# Altium schematic sheet MIXR Power - Connectors.SchDoc
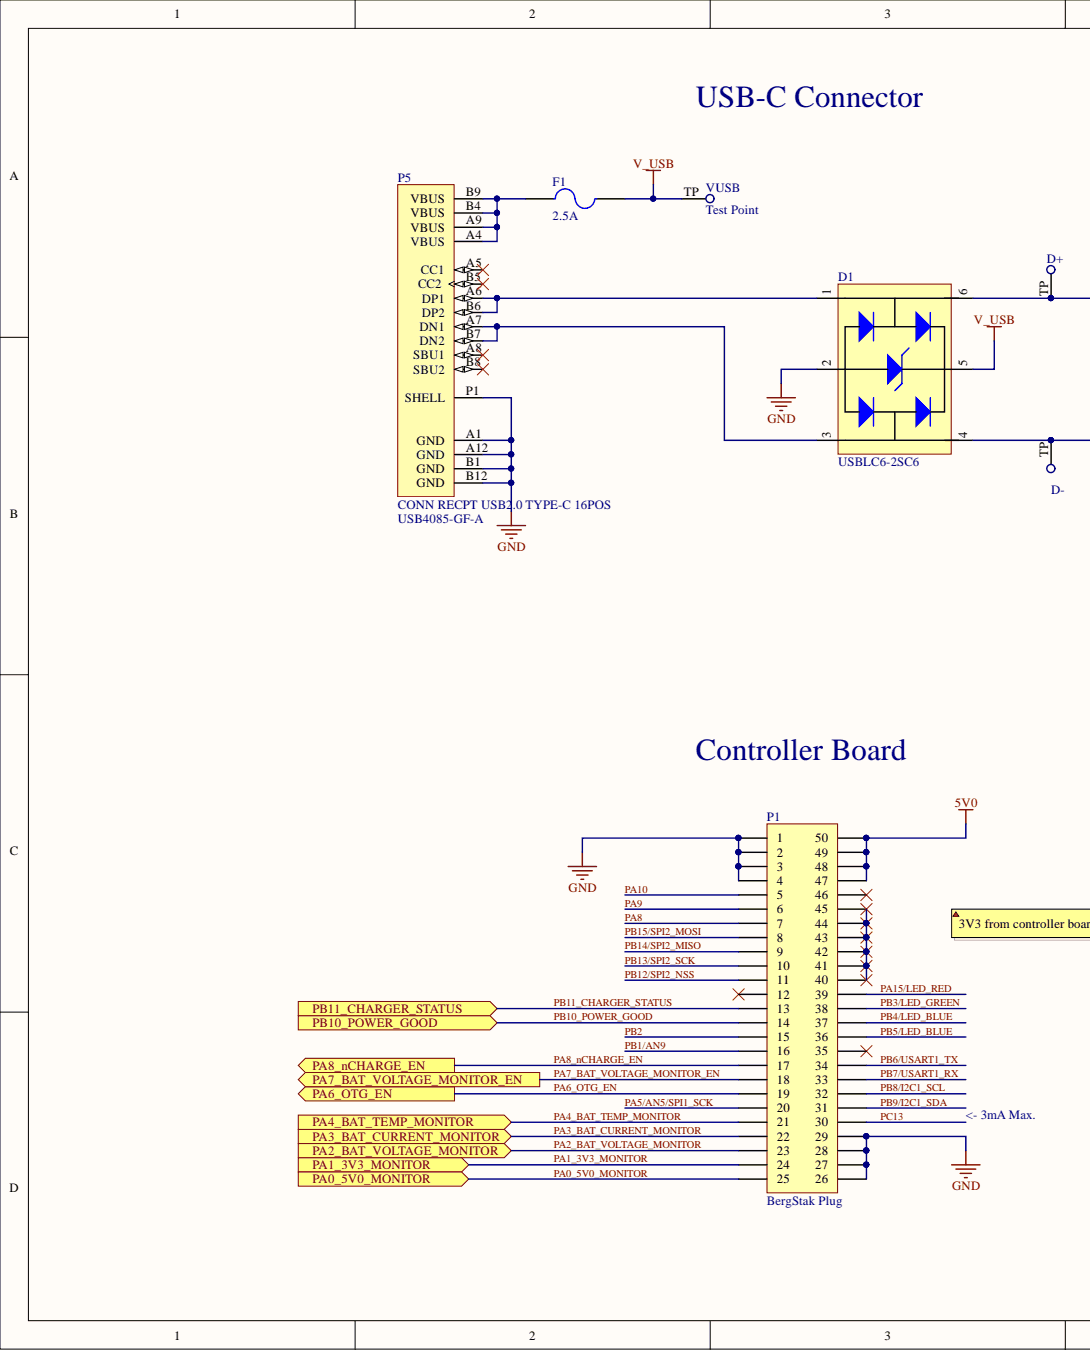
[diagram p0: MIXR Power - Connectors.SchDoc, left]
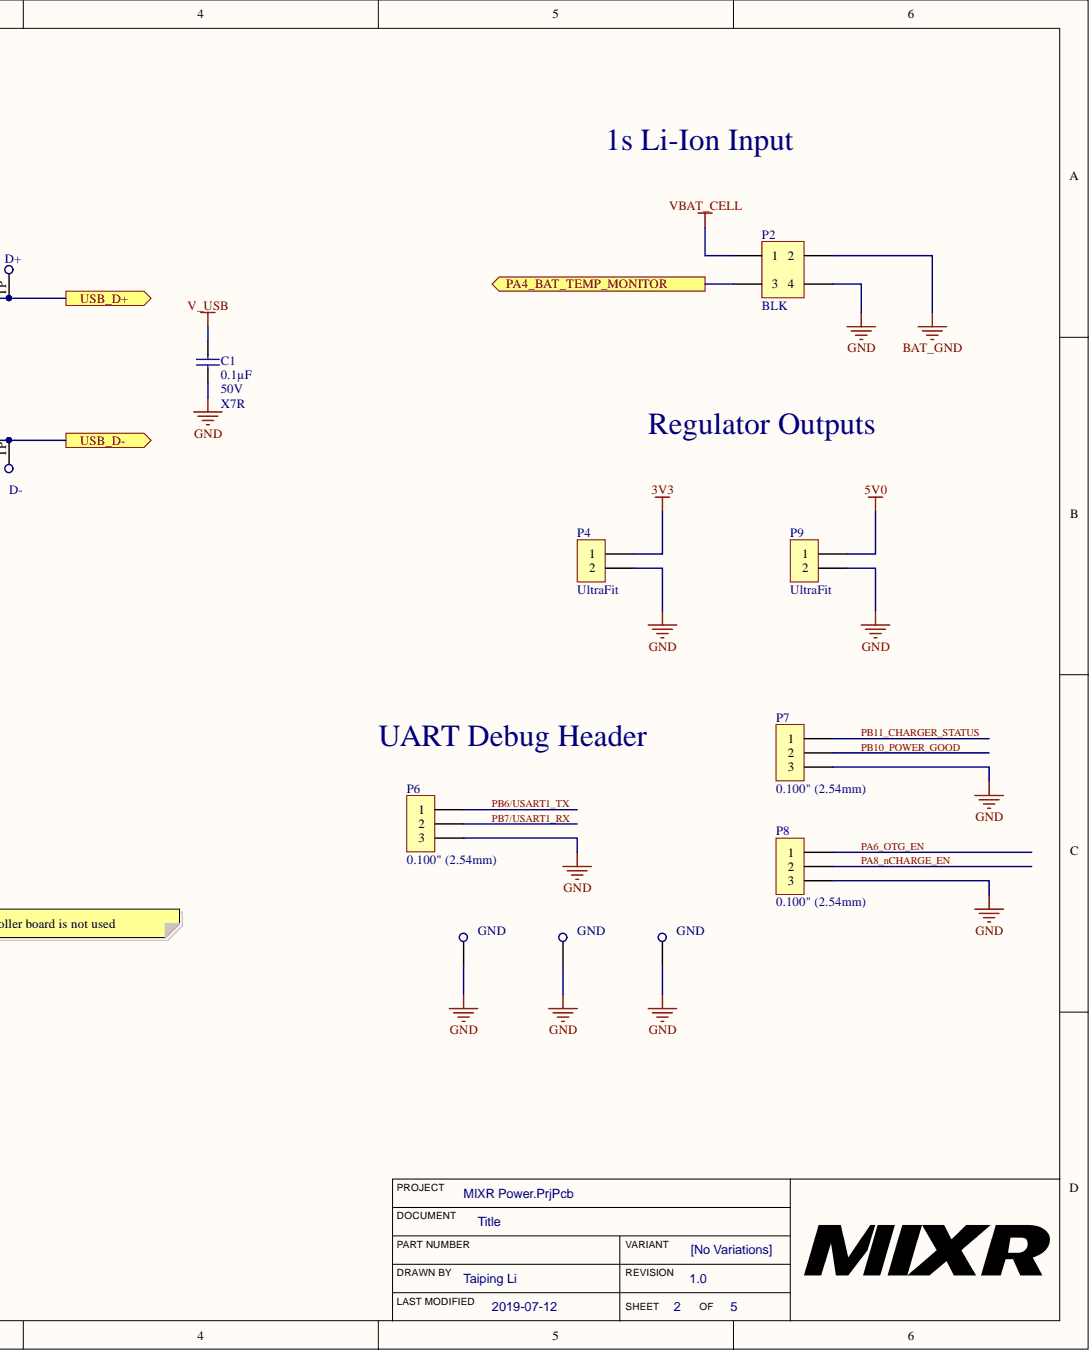
[diagram p1: MIXR Power - Connectors.SchDoc, right]

## Parts
C1  Capacitance: 0.1µF  Temperature Coefficient: X7R  Voltage: 50V  [CAP, 0603]  (hidden comment: CAP CER 0.1UF 50V 10% X7R 0603)
D1  Manufacturer Part Number 1: USBLC6-2SC6  [SOT23-6]  (hidden comment: DIODE TVS 5.25V 17V SOT23-6)
D+  Test Point  [Test Point]  (no BOM)
D-  Test Point  [Test Point]  (no BOM)
F1  Current Rating: 2.5A  [FUSE LITTLEFUSE 0466 1206]  (hidden comment: FUSE 2.5A 32VDC 1206)
GND  Thru Test Point  [Thru Test Point]  (value not drawn)
GND  Thru Test Point  [Thru Test Point]  (value not drawn)
GND  Thru Test Point  [Thru Test Point]  (value not drawn)
P1  Series: BergStak Plug  [CONN, Bergstak 50 Pos Plug]  (hidden comment: CONN 50POS Bergstak Plug 0.02")
P2  BLK  [CONN, 4POS 2 ROW ULTRA-FIT]
P4  Series: UltraFit  [CONN, 2POS ULTRA-FIT]  (hidden comment: CONN 2POS ULTRA-FIT 0.138")
P5  CONN RECPT USB2.0 TYPE-C 16POS  Manufacturer Part Number: USB4085-GF-A  [CONN RECPT USB2.0 TYPE C 16-POS]
P6  Insulation Height: 0.100" (2.54mm)  [CONN, 3POS 0.100" Header, 1 ROW]  (hidden comment: CONN 3POS HEADR MALE 0.1")
P7  Insulation Height: 0.100" (2.54mm)  [CONN, 3POS 0.100" Header, 1 ROW]  (hidden comment: CONN 3POS HEADR MALE 0.1")
P8  Insulation Height: 0.100" (2.54mm)  [CONN, 3POS 0.100" Header, 1 ROW]  (hidden comment: CONN 3POS HEADR MALE 0.1")
P9  Series: UltraFit  [CONN, 2POS ULTRA-FIT]  (hidden comment: CONN 2POS ULTRA-FIT 0.138")
VUSB  Test Point  [Test Point]  (no BOM)

## Ports
PA0_5V0_MONITOR, PA1_3V3_MONITOR, PA2_BAT_VOLTAGE_MONITOR, PA3_BAT_CURRENT_MONITOR, PA4_BAT_TEMP_MONITOR, PA6_OTG_EN, PA7_BAT_VOLTAGE_MONITOR_EN, PA8_nCHARGE_EN, PB10_POWER_GOOD, PB11_CHARGER_STATUS, USB_D+, USB_D-

## Nets
3V3: P4.1
5V0: P1.47 P1.48 P1.49 P1.50 P9.1
BAT_GND: P2.2
GND: C1.2 D1.2 GND.TH_TP P1.1 P1.2 P1.3 P1.4 P1.26 P1.27 P1.28 P1.29 P2.4 P4.2 P5.A1(GND) P5.A12(GND) P5.B1(GND) P5.B12(GND) P5.P1(SHELL) P6.3 P7.3 P8.3 P9.2
V_USB: C1.1 D1.5 F1.2 VUSB.TP
VBAT_CELL: P2.1
PA0_5V0_MONITOR: P1.25 ; port PA0_5V0_MONITOR
PA1_3V3_MONITOR: P1.24 ; port PA1_3V3_MONITOR
PA2_BAT_VOLTAGE_MONITOR: P1.23 ; port PA2_BAT_VOLTAGE_MONITOR
PA3_BAT_CURRENT_MONITOR: P1.22 ; port PA3_BAT_CURRENT_MONITOR
PA4_BAT_TEMP_MONITOR: P1.21 P2.3 ; port PA4_BAT_TEMP_MONITOR
PA5/AN5/SPI1_SCK: P1.20
PA6_OTG_EN: P1.19 P8.1 ; port PA6_OTG_EN
PA7_BAT_VOLTAGE_MONITOR_EN: P1.18 ; port PA7_BAT_VOLTAGE_MONITOR_EN
PA8: P1.7
PA8_nCHARGE_EN: P1.17 P8.2 ; port PA8_nCHARGE_EN
PA9: P1.6
PA10: P1.5
PA15/LED_RED: P1.39
PB1/AN9: P1.16
PB2: P1.15
PB3/LED_GREEN: P1.38
PB4/LED_BLUE: P1.37
PB5/LED_BLUE: P1.36
PB6/USART1_TX: P1.34 P6.1
PB7/USART1_RX: P1.33 P6.2
PB8/I2C1_SCL: P1.32
PB9/I2C1_SDA: P1.31
PB10_POWER_GOOD: P1.14 P7.2 ; port PB10_POWER_GOOD
PB11_CHARGER_STATUS: P1.13 P7.1 ; port PB11_CHARGER_STATUS
PB12/SPI2_NSS: P1.11
PB13/SPI2_SCK: P1.10
PB14/SPI2_MISO: P1.9
PB15/SPI2_MOSI: P1.8
PC13: P1.30
NetD1_1: D1.1 P5.A6(DP1) P5.B6(DP2)
NetD1_3: D1.3 P5.A7(DN1) P5.B7(DN2)
NetD1_4: D1.4 D-.TP
NetD1_6: D1.6 D+.TP
NetF1_1: F1.1 P5.A4(VBUS) P5.A9(VBUS) P5.B4(VBUS) P5.B9(VBUS)
NetP1_40: P1.40 P1.41 P1.42 P1.43 P1.44 P1.45

Not connected: P1 12 35 46; P5 A5(CC1) A8(SBU1) B5(CC2) B8(SBU2)

## Library data of the parts
C1 = CAP CER 0.1UF 50V 10% X7R 0603: 0.10µF ±10% 50V Ceramic Capacitor X7R 0603 (1608 Metric); Manufacturer 1: AVX Corporation; Manufacturer Part Number 1: 06035C104KAT2A; Component Kind: Standard; ComponentLink1Description: http://datasheets.avx.com/X7RDielectric.pdf; ComponentLink1URL: http://datasheets.avx.com/X7RDielectric.pdf; Height - Seated (Max): -; Lead Spacing: -; Lead Style: -; Mounting Type: Surface Mount, MLCC; Operating Temperature: -55°C ~ 125°C; Package / Case: 0603 (1608 Metric); Packaging: Cut Tape (CT); Part Status: Active; Ratings: -; Size / Dimension: 0.063" L x 0.032" W (1.60mm x 0.81mm); Supplier 1: Digi-Key; Supplier Part Number 1: 478-5052-1-ND; Thickness (Max): 0.035" (0.90mm); Tolerance: ±10%; Library Name: Schematic Diagrams.SchLib
D1 = DIODE TVS 5.25V 17V SOT23-6: 17V Clamp 5A (8/20µs) Ipp Tvs Diode Surface Mount SOT-23-6; Manufacturer 1: STMicroelectronics; Breakdown Voltage: 6V; Capacitance: 3.5pF; Data Rate: 480Mbps; Direction: Unidirectional; Element Configuration: Dual; ESD Protection: Yes; Leakage Current: 150nA; Number of Bidirectional Channels: 2; Number of Elements: 1; Operating Supply Voltage: 1.1V; Test Current: 1mA; Working Voltage: 5V; Case/Package: SOT-23; Clamping Voltage: 17V; Component Kind: Standard; ComponentLink1Description: https://datasheet.ciiva.com/1268/1690354-1268384.pdf?src-supplier=Element14; ComponentLink1URL: https://datasheet.ciiva.com/1268/1690354-1268384.pdf?src-supplier=Element14; ComponentLink2Description: https://datasheet.ciiva.com/4508/cd00050750-113117-4508433.pdf?src-supplier=Mouser; ComponentLink2URL: https://datasheet.ciiva.com/4508/cd00050750-113117-4508433.pdf?src-supplier=Mouser; ComponentLink3Description: https://datasheet.ciiva.com/15143/getdatasheetpartid-148465-15143789.pdf?src-supplier=Verical; ComponentLink3URL: https://datasheet.ciiva.com/15143/getdatasheetpartid-148465-15143789.pdf?src-supplier=Verical; ComponentLink4Description: https://datasheet.ciiva.com/26787/usblc6-2sc6-26787908.pdf?src-supplier=IIIC; ComponentLink4URL: https://datasheet.ciiva.com/26787/usblc6-2sc6-26787908.pdf?src-supplier=IIIC; ComponentLink5Description: https://datasheet.ciiva.com/16200/cd00050750-16200026.pdf?src-supplier=Future+Electronics; ComponentLink5URL: https://datasheet.ciiva.com/16200/cd00050750-16200026.pdf?src-supplier=Future+Electronics; ComponentLink6Description: https://datasheet.ciiva.com/41444/001184109-da-01-en-ic-esd-protecti-usblc6-2sc6-sot-23-6-stm-41444156.pdf?src-supplier=Conrad; ComponentLink6URL: https://datasheet.ciiva.com/41444/001184109-da-01-en-ic-esd-protecti-usblc6-2sc6-sot-23-6-stm-41444156.pdf?src-supplier=Conrad; Contact Plating: Tin; Depth: 1.75mm; Height: 1.3mm; Lead Free: Lead Free; Length: 3.05mm; Max Breakdown Voltage: 6V; Max Operating Temperature: 125°C; Max Reverse Leakage Current: 150nA; Min Breakdown Voltage: 6V; Min Operating Temperature: -40°C; Mount: Surface Mount; Number of Channels: 2; Number of Pins: 6; Package Quantity: 3000; Packaging: Tape and Reel; Peak Pulse Current: 5A; Polarity: Unidirectional; Power Line Protection: Yes; Pricing 1: 1=0.47000, 10=0.39900, 100=0.29820, 500=0.23430, 1000=0.18105 (USD); Radiation Hardening: No; REACH SVHC: No SVHC; Reverse Breakdown Voltage: 6V; Reverse Standoff Voltage: 5.25V; RoHS: Yes; Stock 1: 7579; Supplier 1: Digi-Key; Supplier Part Number 1: 497-5235-1-ND; Termination: SMD/SMT; Width: 1.75mm; Library Name: Schematic Diagrams.SchLib
F1 = FUSE 2.5A 32VDC 1206: 2.5A 32V AC 32V DC Fuse Board Mount (Cartridge Style Excluded) Surface Mount 1206 (3216 Metric); Manufacturer 1: Littelfuse; Manufacturer Part Number 1: 046602.5NRHF; Approvals: UL; DC Cold Resistance: 24mR; Power Dissipation: 192.5mW; Case/Package: 1206; Case Code (Imperial): 1206; Case Code (Metric): 3216; Component Kind: Standard; ComponentLink1Description: https://datasheet.ciiva.com/303/littelfuse-fuse-466-303217.pdf?src-supplier=Digi-Key; ComponentLink1URL: https://datasheet.ciiva.com/303/littelfuse-fuse-466-303217.pdf?src-supplier=Digi-Key; ComponentLink2Description: https://datasheet.ciiva.com/18652/littelfuse-fuse-466-datasheet-pdf-18652469.pdf?src-supplier=Digi-Key; ComponentLink2URL: https://datasheet.ciiva.com/18652/littelfuse-fuse-466-datasheet-pdf-18652469.pdf?src-supplier=Digi-Key; ComponentLink3Description: https://datasheet.ciiva.com/16327/littelfuse-fuse-466-16327471.pdf?src-supplier=Future+Electronics; ComponentLink3URL: https://datasheet.ciiva.com/16327/littelfuse-fuse-466-16327471.pdf?src-supplier=Future+Electronics; Depth: 1.52mm; Fuse Type: Board Mount (Cartridge Style Excluded); Height: 0.023inch; Housing Material: Ceramic; Lead Free: Lead Free; Length: 3.18mm; Max Operating Temperature: 90°C; Min Operating Temperature: -55°C; Mount: Surface Mount; Package Quantity: 5000; Packaging: Tape and Reel; Pricing 1: 1=0.84000, 5=0.81200, 10=0.78700, 50=0.66180, 100=0.54930, 250=0.49940, 500=0.42450, 1000=0.37455 (USD); RoHS: Yes; Stock 1: 4995; Supplier 1: Digi-Key; Supplier Part Number 1: F6143CT-ND; Voltage Rating (AC): 32V; Voltage Rating (DC): 32V; Width: 0.06inch; Working Voltage: 32V; Library Name: Schematic Diagrams.SchLib
P1 = CONN 50POS Bergstak Plug 0.02": 50 Position Connector Plug, Outer Shroud Contacts Surface Mount Gold; Manufacturer 1: Amphenol FCI; Manufacturer Part Number 1: 10132797-055100LF; Mated Stacking Heights: 5mm; Number of Positions: 50; Pitch: 0.020" (0.50mm); Component Kind: Standard; ComponentLink1Description: http://portal.fciconnect.com/Comergent//fci/drawing/10132797.pdf; ComponentLink1URL: http://portal.fciconnect.com/Comergent//fci/drawing/10132797.pdf; Connector Type: Plug, Outer Shroud Contacts; Contact Finish: Gold; Contact Finish Thickness: 1µin (0.025µm); Height Above Board: 0.169" (4.30mm); Mounting Type: Surface Mount; Number of Rows: 2; Packaging: Cut Tape (CT); Part Status: Active; Supplier 1: Digi-Key; Supplier Part Number 1: 609-5226-1-ND; Library Name: Schematic Diagrams.SchLib
P2 = CONN 4POS 2 ROW ULTRA-FIT 0.138": Connector Header Through Hole 4 position 0.138" (3.50mm); Manufacturer 1: Molex; Manufacturer Part Number 1: 1722991104; Connector Type: Male Pin; Description 1: ULTRA-FIT HDR VT DUAL F BLK 04CKT TIN; Number of Positions: 4; Pitch: 0.138inch; Row Spacing: 0.181inch; Colour: Black; Component Kind: Standard; ComponentLink1Description: http://www.literature.molex.com/SQLImages/kelmscott/Molex/PDF_Images/987651-1008.pdf; ComponentLink1URL: http://www.literature.molex.com/SQLImages/kelmscott/Molex/PDF_Images/987651-1008.pdf; Contact Plating: Tin; Fastening Type: Latch; Mount: Through Hole; Number of Rows: 2; Packaging: Tray; Pricing 1: 1=0.86, 10=0.753, 100=0.6494, 500=0.53136, 1000=0.47232, 5000=0.41328, 10000=0.39262 (USD); Stock 1: 958; Supplier 1: Digi-Key; Supplier Part Number 1: WM11777-ND; Termination: Solder; Library Name: Schematic Diagrams.SchLib
P4 P9 = CONN 2POS ULTRA-FIT 0.138": Connector Header Through Hole 2 position 0.138" (3.50mm); Manufacturer: Molex; Manufacturer Part Number: 1722871102; Connector Type: Male Pin; Number of Positions: 2; Pitch: 0.138inch; Color: Black; Component Kind: Standard; ComponentLink1Description: http://www.molex.com/pdm_docs/sd/1722861302_sd.pdf; ComponentLink1URL: http://www.molex.com/pdm_docs/sd/1722861302_sd.pdf; Contact Plating: Tin; Fastening Type: Latch; Mount: Through Hole; Number of Rows: 1; Packaging: Tray; Pricing 1: 1 = 0.78000, 10 = 0.68800, 100 = 0.59380, 500 = 0.48582, 1000 = 0.43184, 5000 = 0.37786, 10000 = 0.35897 (USD); RoHS: Yes; Stock 1: 2743; Supplier 1: Digi-Key; Supplier Part Number 1: WM11701-ND; Termination: Solder; Library Name: Schematic Diagrams.SchLib
P5 = CONN RECPT USB2.0 TYPE-C 16POS: USB - C USB 2.0 Receptacle Connector 16 Position Through Hole, Right Angle; Manufacturer: Global Connector Technology; Component Kind: Standard; Pricing 1: 1 = 1.440000, 10 = 1.307000, 25 = 1.227200, 50 = 1.174000, 100 = 1.120600, 250 = 1.013840 (USD); RoHS: No; Stock 1: 286; Supplier 1: Digi-Key; Supplier Part Number 1: 2073-USB4085-GF-ACT-ND; Library Name: Schematic Diagrams.SchLib
P6-P8 = CONN 3POS HEADR MALE 0.1": 3 Positions Header Connector 0.100" (2.54mm) Through Hole Gold; Manufacturer 1: Wurth Electronics Inc.; Manufacturer Part Number 1: 61300311121; Contact Length - Mating: 0.236" (6.00mm); Contact Length - Post: 0.118" (3.00mm); Current Rating: 3A; Material Flammability Rating: UL94 V-0; Number of Positions: 3; Number of Positions Loaded: All; Overall Contact Length: 0.454" (11.54mm); Shrouding: Unshrouded; Style: Board to Board or Cable; Voltage: 250V; Component Kind: Standard; ComponentLink1Description: http://katalog.we-online.de/em/datasheet/6130xx11121.pdf; ComponentLink1URL: http://katalog.we-online.de/em/datasheet/6130xx11121.pdf; Connector Type: Header; Contact Finish - Mating: Gold; Contact Finish - Post: -; Contact Finish Thickness - Mating: -; Contact Finish Thickness - Post: -; Contact Material: Copper Alloy; Contact Shape: Square; Contact Type: Male Pin; Fastening Type: -; Ingress Protection: -; Insulation Color: Black; Insulation Material: Polybutylene Terephthalate (PBT); Mated Stacking Heights: -; Mounting Type: Through Hole; Number of Rows: 1; Operating Temperature: -40°C ~ 125°C; Packaging: Bulk; Part Status: Active; Pitch - Mating: 0.100" (2.54mm); Row Spacing - Mating: -; Supplier 1: Digi-Key; Supplier Part Number 1: 732-5316-ND; Termination: Solder; Library Name: Schematic Diagrams.SchLib
GND GND GND = Thru Test Point: Component Kind: Standard; Library Name: Schematic Diagrams.SchLib
D+ D- VUSB = Test Point: Library Name: Schematic Diagrams.SchLib
